# Altium schematic sheet RPI-TPS-GK - Power Supply.SchDoc
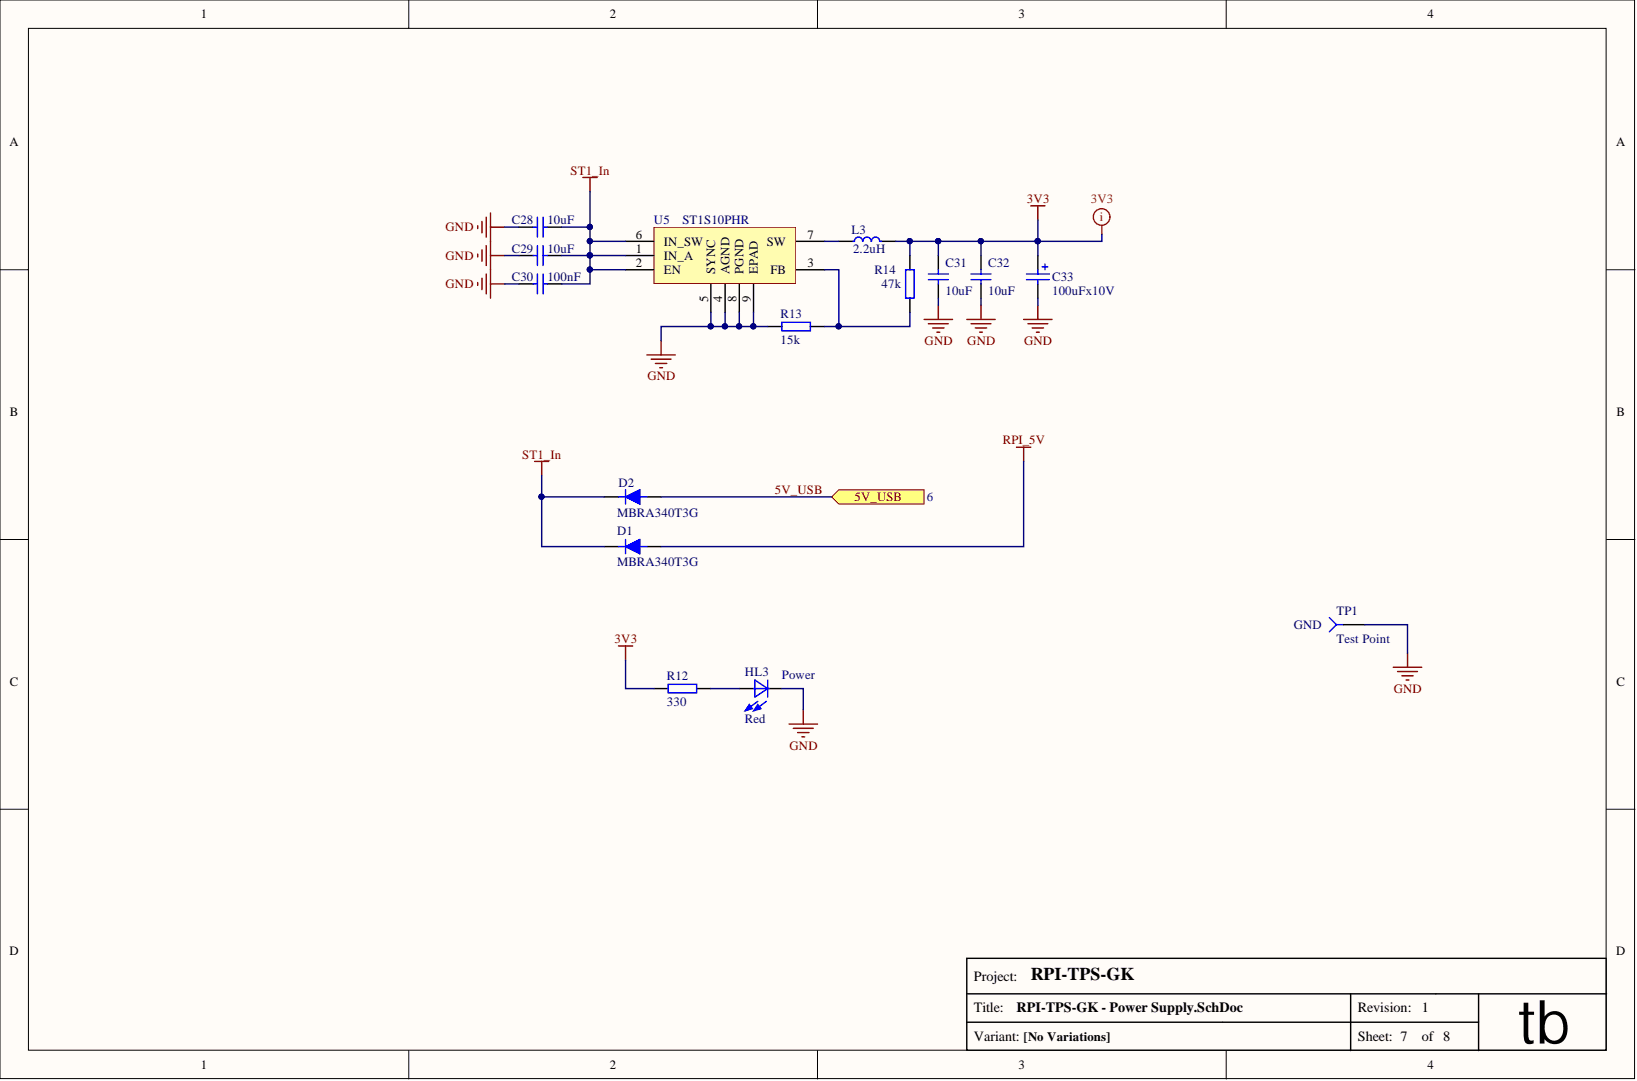
[diagram p0: RPI-TPS-GK - Power Supply.SchDoc]

## Parts
C28  value 10uF  [C0805]  (hidden comment: CAP)
C29  value 10uF  [C0805]  (hidden comment: CAP)
C30  value 100nF  [C0603]  (hidden comment: CAP)
C31  value 10uF  [C0805]  (hidden comment: CAP)
C32  value 10uF  [C0805]  (hidden comment: CAP)
C33  value 100uFx10V  [CAP_CASE_C]  (hidden comment: uF)
D1  value MBRA340T3G  Manufacturer Part: MBRA340T3G  [SMA]  (hidden comment: Diode)
D2  value MBRA340T3G  Manufacturer Part: MBRA340T3G  [SMA]  (hidden comment: Diode)
HL3  Power  value Red  [0805_LED_red]
L3  value 2.2uH  [LTF5022]  (hidden comment: L_LTF5022)
R12  value 330  [0603_RESISTOR]  (hidden comment: Resistor)
R13  value 15k  [0603_RESISTOR]  (hidden comment: Resistor)
R14  value 47k  [0603_RESISTOR]  (hidden comment: Resistor)
TP1  GND  value Test Point  [TP-Blue]
U5  ST1S10  value ST1S10PHR  Manufacturer Part: ST1S10PHR  [SO8_PWR_pad]

## Ports
5V_USB

## Nets
3V3: C31.2 C32.2 C33.1 L3.2 R12.2 R14.1
GND: C28.1 C29.1 C30.2 C31.1 C32.1 C33.2 HL3.2 R13.1 TP1.1 U5.4(AGND) U5.5(SYNC) U5.8(PGND) U5.9(EPAD)
RPI_5V: D1.1
ST1_In: C28.2 C29.2 C30.1 D1.2 D2.2 U5.1(IN_A) U5.2(EN) U5.6(IN_SW)
5V_USB: D2.1 ; port 5V_USB
NetHL3_1: HL3.1 R12.1
NetL3_1: L3.1 U5.7(SW)
NetR13_2: R13.2 R14.2 U5.3(FB)

## Library data of the parts
C28 C29 C31 C32 = CAP: Manufacturer: Murata; Manufacturer Part: GRM21BC81C106KE15L; Type of Component: Ceramic Capacitor; Component Kind: Standard; Manufacturer Link: http://psearch.en.murata.com/capacitor/result/smd/?status=all&pid=GRM21BC81C106KE15L; Package / Case: 0805; Supplier 1: aliexpress.com; Supplier 1 Link: aliexpress.com/store/product/100pcs-lot-10uF-X7R-Error-10-25V-0805-smd-capacitor/506373_32319279591.html?detailNewVersion=&categoryId=141908&spm=2114.12010208.1000023.190.luw8Z7; Supplier 2: http://imrad.com.ua; Supplier 2 Link: http://imrad.com.ua/ru/cc0805zry5v8bb105; Supplier 2 Part: 189682; Library Name: RPI-VITA.IntLib
C30 = CAP: Manufacturer: Vishay / Vitramon; Manufacturer Part: VJ0603Y104JXJPW1BC; Type of Component: Ceramic Capacitor; Component Kind: Standard; Manufacturer Link: http://www.vishay.com/product?docid=28548; Package / Case: 0603; Supplier 1: aliexpress.com; Supplier 1 Link: aliexpress.com/item/100pcs-lot-100nF-X7R-Error-10-50V-0603-smd-capacitor/32318089455.html?spm=2114.03010208.3.2.PDNk2A&ws_ab_test=searchweb201556_8,searchweb201602_1_10065_10068_112_10069_110_111_418_10017_109_108_10060_10061_10062_10057_10039_10056_10055_10054_10059_10058_10073_10070_10052_10053_10050_10051,searchweb201603_1&btsid=c39062ca-3db8-4562-8db0-c782461646c7; Supplier 2: http://imrad.com.ua/; Supplier 2 Link: http://imrad.com.ua/ru/cc0603krx7r8bb104; Supplier 2 Part: 182925; Supplier 3: http://voron.ua; Supplier 3 Link: http://voron.ua/catalog/001832; Supplier 3 Part: 001832; Library Name: RPI-VITA.IntLib
C33 = Cap_pol_tant: Manufacturer: AVX; Type of Component: Tantalum Capacitor; Component Kind: Standard; Package / Case: Case C; Supplier 1: aliexpress.com; Supplier 1 Link: aliexpress.com/item/100PCS-C-10V-100UF-C-107-6032-C-SMD-tantalum-capacitor/32345604565.html?spm=2114.031010208.3.2.8QXoyw&ws_ab_test=searchweb201556_1%2Csearchweb201644_5_10001_10002_10005_10006_10003_10004_62%2Csearchweb201560_2%2Csearchweb1451318400_6150; Supplier 2: http://imrad.com.ua/; Supplier 2 Link: http://imrad.com.ua/ru/tecap-100-10v-c-10-293d107x9010c2te3; Supplier 2 Part: 166131; Supplier 3: http://voron.ua/; Supplier 3 Link: http://voron.ua/catalog/014231; Supplier 3 Part: 014231; Library Name: RPI-VITA.IntLib
D1 D2 = Diode: Schottky Diodes & Rectifiers; Manufacturer: ONS; Component Kind: Standard; Package / Case: SMA; Supplier 1: aliexpress.com; Supplier 1 Link: aliexpress.com/item/MBRA340-MBRA340T3G-DIODE-SCHOTTKY-40V-3A-SMA/1944352353.html?spm=2114.03010208.3.28.8q9wBv&ws_ab_test=searchweb0_0,searchweb201602_1_10065_10068_10501_10503_10000032_119_10000025_10000029_430_10000028_10060_10062_10056_10055_10000062_10054_10059_10099_10000022_10000012_10103_10000015_10102_10096_10000018_10000019_10000056_10000059_10052_10053_10107_10050_10106_10051_10000053_10000007_10000050_10117_10084_10083_10118_10000047_10080_10082_10081_10110_10111_10112_10113_10114_10115_10000041_10000044_10078_10079_10000038_429_10073_10000035_10121-10503_10501,searchweb201603_1,afswitch_1,single_sort_1_default&btsid=cfe73762-16d2-43de-9d9c-060e9c0daffa; Supplier 1 Part: MBRA340T3G; Supplier 2: http://imrad.com.ua/; Supplier 2 Link: http://imrad.com.ua/ru/mbra340t3g; Supplier 2 Part: 147028; Type of Component: Diode; Library Name: RPI-VITA.IntLib
HL3 = LED: Manufacturer Part: 0805 Led Red; Component Kind: Standard; Package / Case: 0805; Supplier 1: aliexpress.com; Supplier 1 Link: aliexpress.com/store/product/FREE-SHIPPING-1000PCS-0805-2012-Red-Clear-Ultra-Bright-SMD-LED-Indication/102034_32593014437.html?detailNewVersion=&categoryId=400101&spm=2114.12010208.1000023.27.PnNou5; Supplier 1 Part: 0805 Led Red; Supplier 2: http://imrad.com.ua/; Supplier 2 Link: http://imrad.com.ua/ru/fyls-0805burc; Supplier 2 Part: 179813; Supplier 3: http://voron.ua/; Supplier 3 Link: http://voron.ua/catalog/023355; Supplier 3 Part: 023355; Type of Component: Led; Library Name: RPI-VITA.IntLib
L3 = L_LTF5022: Manufacturer: TDK; Manufacturer Part: LTF5022T-2R2N3R2-LC; Type of Component: Inductor; Component Kind: Standard; Manufacturer Link: http://product.tdk.com/inductor/ind/detailed_information.php?lang=en&part_no=LTF5022T-2R2N3R2-LC; Package / Case: 5.2 mm x 5 mm; Library Name: RPI-VITA.IntLib
R12 = Resistor: Manufacturer: UniOhm; Manufacturer Part: 0603 330; Component Kind: Standard; Package / Case: 0603; Supplier 1: aliexpress.com; Supplier 1 Link: aliexpress.com/store/product/0603-SMD-Resistor-1-330-ohm-5000pcs-lot/506373_32307375865.html?detailNewVersion=&categoryId=400503&spm=2114.12010208.1000023.227.iOPgvR; Supplier 1 Part: 0603 330; Supplier 2: http://imrad.com.ua/; Supplier 2 Link: http://imrad.com.ua/ru/res-0603-330r-1; Supplier 2 Part: 181872; Type of Component: Resistor; Library Name: RPI-VITA.IntLib
R13 = Resistor: Manufacturer: Vishay / Dale; Manufacturer Part: CRCW060315K0FKEA; Component Kind: Standard; Manufacturer Link: http://www.vishay.com/product?docid=20035; Package / Case: 0603; Supplier 1: aliexpress.com; Supplier 1 Link: aliexpress.com/store/product/0603-SMD-Resistor-1-15K-ohm-5000pcs-lot/506373_32308168978.html?detailNewVersion=&categoryId=400503&spm=2114.12010208.1000023.80.e50PkY; Supplier 1 Part: 0603 15k; Supplier 2: http://imrad.com.ua/; Supplier 2 Link: http://imrad.com.ua/ru/res-0603-15k-5-product-65654; Supplier 2 Part: 141810; Supplier 3: http://voron.ua/; Supplier 3 Link: http://voron.ua/catalog/014910; Supplier 3 Part: 014910; Type of Component: Resistor; Library Name: RPI-VITA.IntLib
R14 = Resistor: Manufacturer: Vishay / Dale; Manufacturer Part: CRCW060347K0FKEA; Component Kind: Standard; Manufacturer Link: http://www.vishay.com/product?docid=20035; Package / Case: 0603; Supplier 1: aliexpress.com; Supplier 1 Link: aliexpress.com/store/product/0603-SMD-Resistor-1-47K-ohm-5000pcs-lot/506373_32307371682.html?detailNewVersion=&categoryId=400503&spm=2114.12010208.1000023.138.IWlXZT; Supplier 1 Part: 0603 47k; Supplier 2: http://imrad.com.ua/; Supplier 2 Link: http://imrad.com.ua/ru/rc0603jr-0747kl; Supplier 2 Part: 183869; Supplier 3: http://voron.ua/; Supplier 3 Link: http://voron.ua/catalog/014806; Supplier 3 Part: 014806; Type of Component: Resistor; Library Name: RPI-VITA.IntLib
TP1 = TP: Test Point; Component Kind: Standard; Package / Case: TP-Blue; Supplier 1: aliexpress.com; Supplier 1 Link: aliexpress.com/item/Test-3D-Six-color-1000pcs-lot-PCB-board-test-point-bead-ring-hoop-ceramic-PCB-test/32712556398.html?spm=2114.10010208.1000013.2.RAUhIu&scm=1007.13339.33317.0&pvid=d58a7c4a-009c-4a8a-bf6b-cc82c1d93353&tpp=1; Supplier 1 Part: Blue; Type of Component: Test Point; Library Name: RPI-VITA.IntLib
U5 = ST1S10: Manufacturer: STMicroelectronics; Type of Component: Voltage Regulator; Component Kind: Standard; Manufacturer Link: http://www.st.com/web/en/catalog/sense_power/FM142/CL1456/SC355/PF188180?s_searchtype=keyword; Package / Case: SO-8; Supplier 1: aliexpress.com; Supplier 1 Link: aliexpress.com/item/ST1S10PHR-ST1S10-new-original-quality-assurance-HHDZ2/32694956747.html?spm=2114.03010208.3.10.QmR9CM&ws_ab_test=searchweb0_0,searchweb201602_1_10065_10068_10501_10503_10000032_119_10000025_10000029_430_10000028_10060_10062_10056_10055_10000062_10054_10059_10099_10000022_10000012_10103_10000015_10102_10096_10000018_10000019_10000056_10000059_10052_10053_10107_10050_10106_10051_10000053_10000007_10000050_10117_10084_10083_10118_10000047_10080_10082_10081_10110_10111_10112_10113_10114_10115_10000041_10000044_10078_10079_10000038_429_10073_10000035_10121-10503_10501,searchweb201603_1,afswitch_1,single_sort_1_default&btsid=ce44214a-3bcb-4882-9476-a65a80e8cdb7; Supplier 1 Part: ST1S10PHR; Supplier 2: http://imrad.com.ua/; Supplier 2 Link: http://imrad.com.ua/ru/st1s10phr; Supplier 2 Part: 133755; Library Name: RPI-VITA.IntLib
